# Altium schematic sheet Coil_switch.SchDoc(Coil_switch)
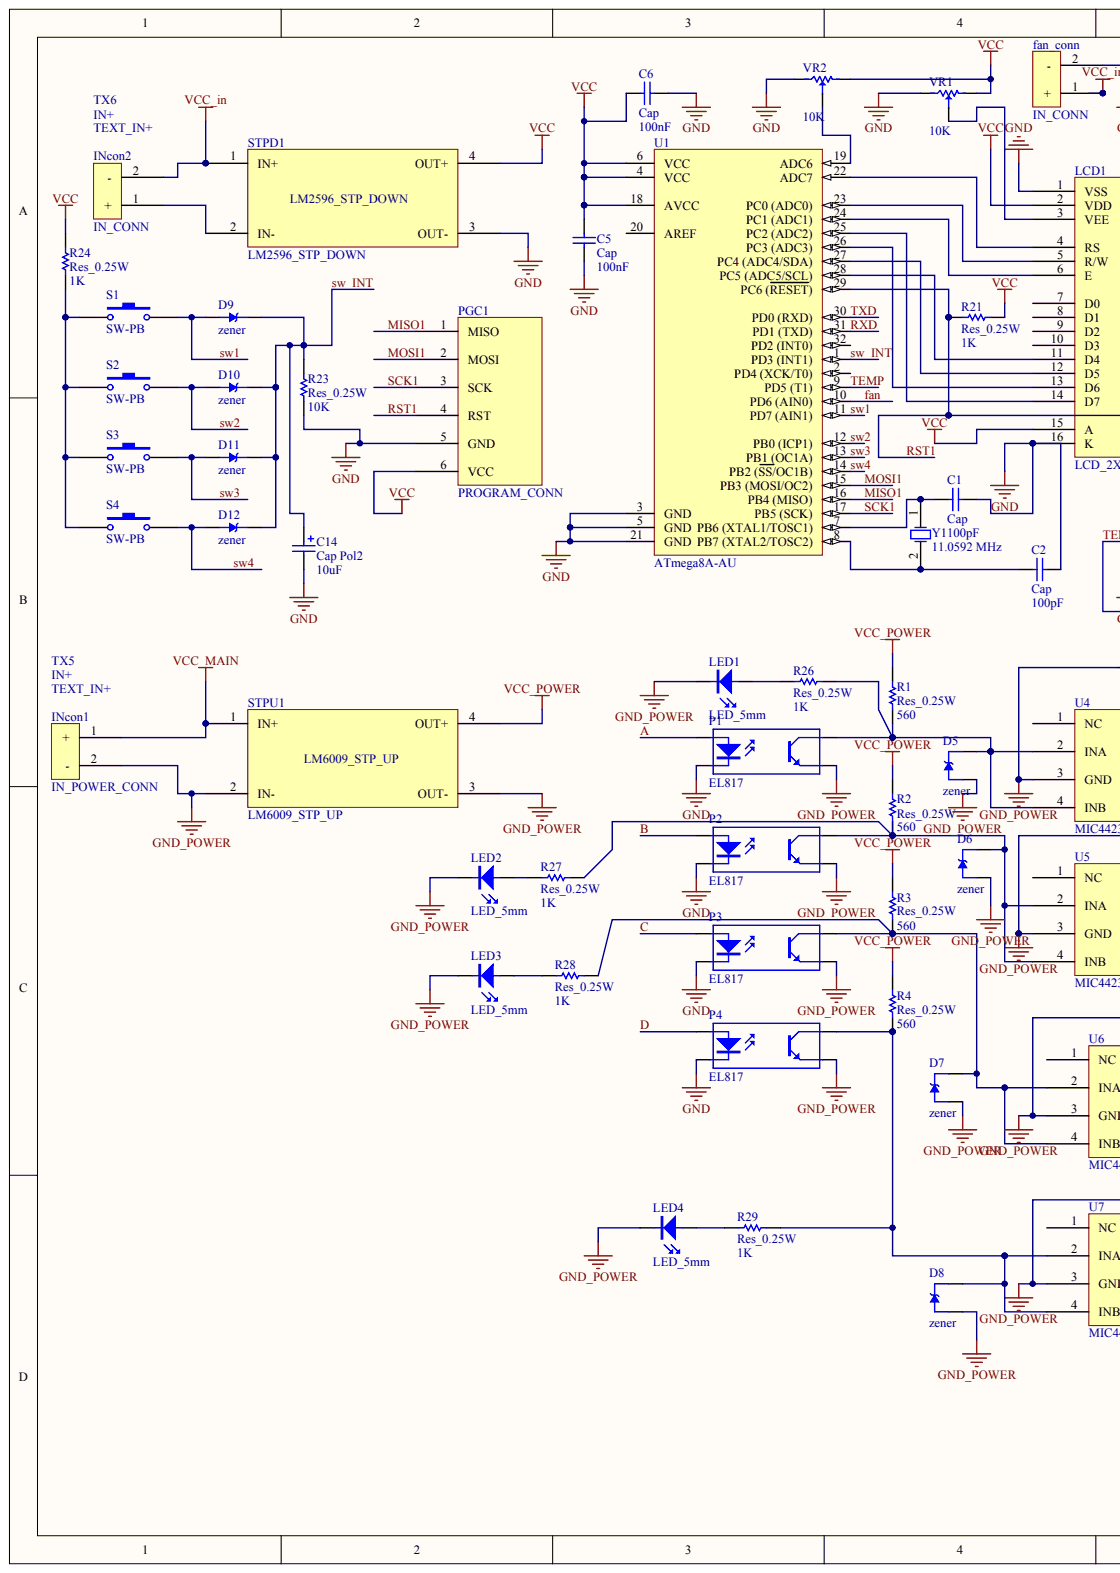
[diagram p0: Coil_switch.SchDoc, left]
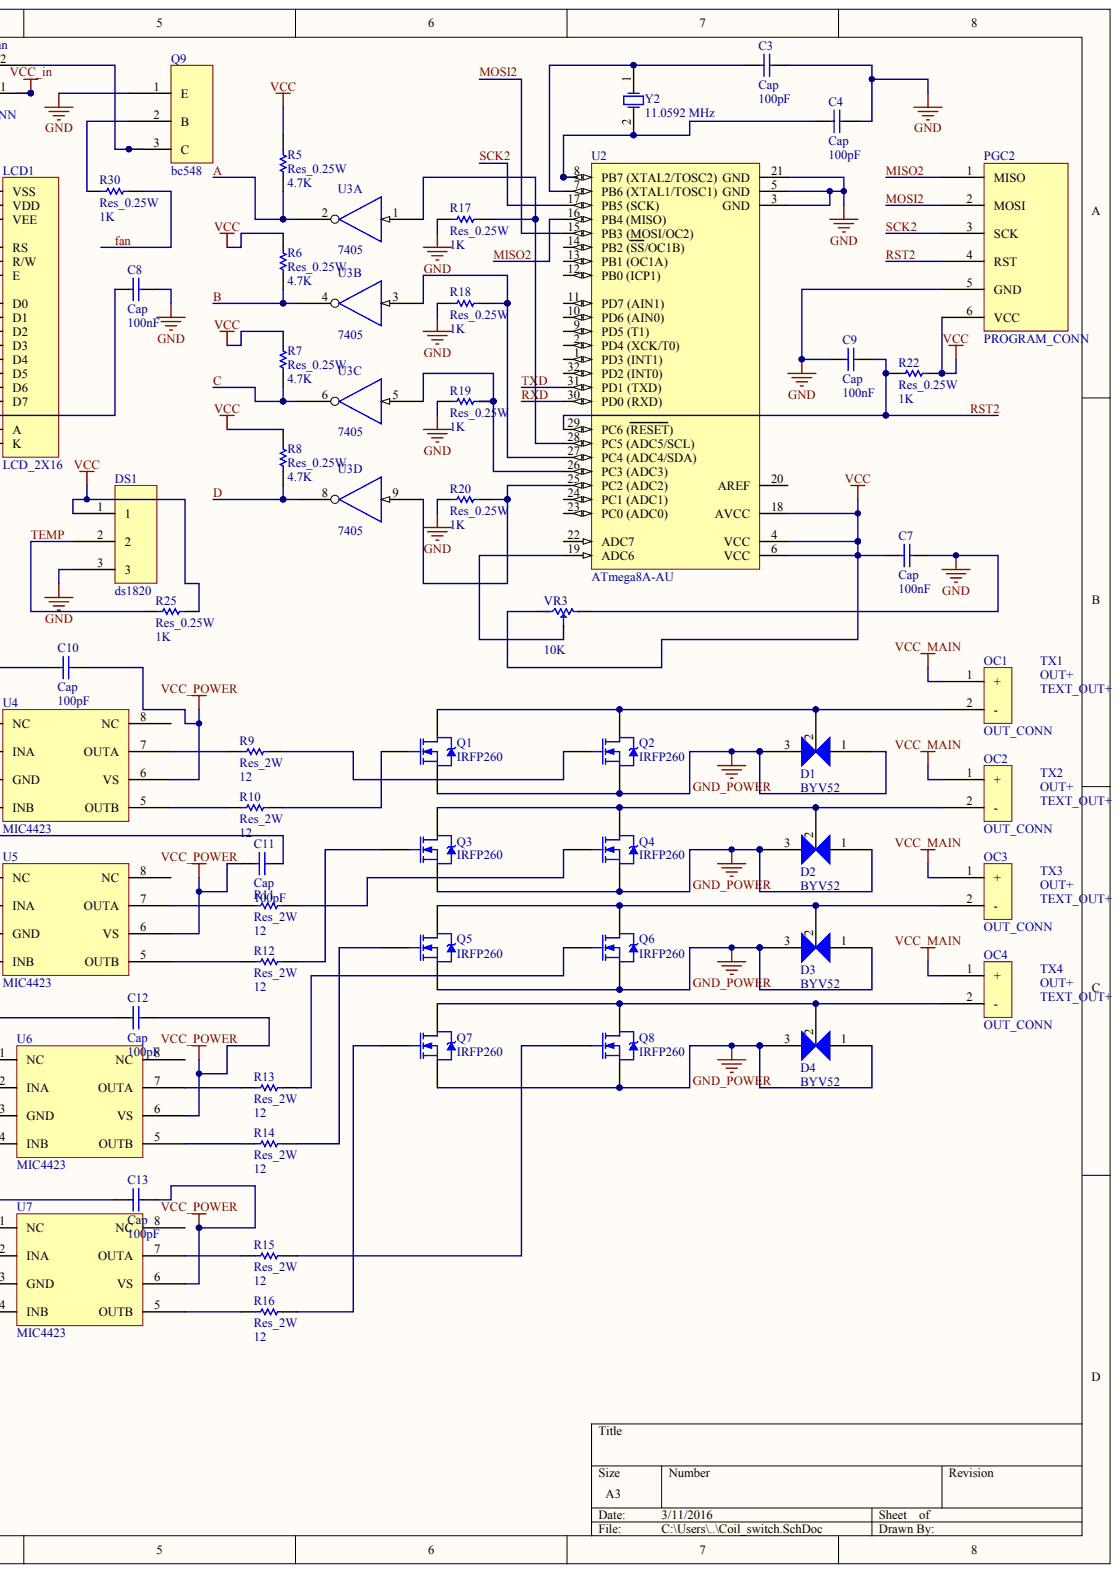
[diagram p1: Coil_switch.SchDoc, right]

## Parts
C1  Cap  value 100pF
C2  Cap  value 100pF
C3  Cap  value 100pF
C4  Cap  value 100pF
C5  Cap  value 100nF
C6  Cap  value 100nF
C7  Cap  value 100nF
C8  Cap  value 100nF
C9  Cap  value 100nF
C10  Cap  value 100pF
C11  Cap  value 100pF
C12  Cap  value 100pF
C13  Cap  value 100pF
C14  Cap Pol2  value 10uF
D1  BYV52
D2  BYV52
D3  BYV52
D4  BYV52
D5  zener
D6  zener
D7  zener
D8  zener
D9  zener
D10  zener
D11  zener
D12  zener
DS1  ds1820
fan_conn  IN_CONN
INcon1  IN_POWER_CONN
INcon2  IN_CONN
LCD1  LCD_2X16
LED1  LED_5mm
LED2  LED_5mm
LED3  LED_5mm
LED4  LED_5mm
OC1  OUT_CONN
OC2  OUT_CONN
OC3  OUT_CONN
OC4  OUT_CONN
P1  EL817
P2  EL817
P3  EL817
P4  EL817
PGC1  PROGRAM_CONN
PGC2  PROGRAM_CONN
Q1  IRFP260
Q2  IRFP260
Q3  IRFP260
Q4  IRFP260
Q5  IRFP260
Q6  IRFP260
Q7  IRFP260
Q8  IRFP260
Q9  bc548
R1  Res_0.25W  value 560
R2  Res_0.25W  value 560
R3  Res_0.25W  value 560
R4  Res_0.25W  value 560
R5  Res_0.25W  value 4.7K
R6  Res_0.25W  value 4.7K
R7  Res_0.25W  value 4.7K
R8  Res_0.25W  value 4.7K
R9  Res_2W
R10  Res_2W  value 12
R11  Res_2W
R12  Res_2W  value 12
R13  Res_2W
R14  Res_2W  value 12
R15  Res_2W
R16  Res_2W  value 12
R17  Res_0.25W  value 1K
R18  Res_0.25W  value 1K
R19  Res_0.25W  value 1K
R20  Res_0.25W  value 1K
R21  Res_0.25W  value 1K
R22  Res_0.25W  value 1K
R23  Res_0.25W  value 10K
R24  Res_0.25W  value 1K
R25  Res_0.25W  value 1K
R26  Res_0.25W  value 1K
R27  Res_0.25W  value 1K
R28  Res_0.25W  value 1K
R29  Res_0.25W  value 1K
R30  Res_0.25W  value 1K
S1  SW-PB
S2  SW-PB
S3  SW-PB
S4  SW-PB
STPD1  LM2596_STP_DOWN
STPU1  LM6009_STP_UP
TX1  TEXT_OUT+
TX2  TEXT_OUT+
TX3  TEXT_OUT+
TX4  TEXT_OUT+
TX5  TEXT_IN+
TX6  TEXT_IN+
U1  ATmega8A-AU
U2  ATmega8A-AU
U3  7405  (parts A,B,C,D)
U4  MIC4423
U5  MIC4423
U6  MIC4423
U7  MIC4423
VR1  10K
VR2  10K
VR3  10K
Y1  11.0592 MHz
Y2  11.0592 MHz

## Nets
GND: C1.2 C2.2 C3.2 C4.2 C5.2 C6.2 C7.2 C8.2 C9.1 C14.2 DS1.3 LCD1.1(VSS) LCD1.16(K) P1.2 P2.2 P3.2 P4.2 PGC1.5(GND) PGC2.5(GND) Q9.1 R17.1 R18.1 R19.1 R20.1 R23.1 STPD1.3(OUT-) U1.3(GND) U1.5(GND) U1.21(GND) U2.3(GND) U2.5(GND) U2.21(GND) U3.7 VR1.1 VR2.1 VR3.2
GND_POWER: C10.1 C11.2 C12.1 C13.1 D1.1 D1.3 D2.1 D2.3 D3.1 D3.3 D4.1 D4.3 D5.1 D6.1 D7.1 D8.1 INcon1.2 LED1.2 LED2.2 LED3.2 LED4.2 P1.3 P2.3 P3.3 P4.3 Q1.3 Q2.3 Q3.3 Q4.3 Q5.3 Q6.3 Q7.3 Q8.3 STPU1.2(IN-) STPU1.3(OUT-) U4.3(GND) U5.3(GND) U6.3(GND) U7.3(GND)
VCC: C5.1 C6.1 C7.1 DS1.1 LCD1.2(VDD) LCD1.15(A) PGC1.6(VCC) PGC2.6(VCC) R5.1 R6.1 R7.1 R8.1 R21.2 R22.2 R24.2 R25.2 STPD1.4(OUT+) U1.4(VCC) U1.6(VCC) U1.18(AVCC) U2.4(VCC) U2.6(VCC) U2.18(AVCC) U3.14 VR1.2 VR2.2 VR3.1
VCC_IN: fan_conn.1 INcon2.2 STPD1.1(IN+)
VCC_MAIN: INcon1.1 OC1.1 OC2.1 OC3.1 OC4.1 STPU1.1(IN+)
VCC_POWER: C10.2 C11.1 C12.2 C13.2 R1.2 R2.2 R3.2 R4.2 STPU1.4(OUT+) U4.6(VS) U5.6(VS) U6.6(VS) U7.6(VS)
A: P1.1 R5.2 U3.2
B: P2.1 R6.2 U3.4
C: P3.1 R7.2 U3.6
D: P4.1 R8.2 U3.8
FAN: R30.2 U1.10(PD6_(AIN0))
MISO1: PGC1.1(MISO) U1.16(PB4_(MISO))
MISO2: PGC2.1(MISO) U2.16(PB4_(MISO))
MOSI1: PGC1.2(MOSI) U1.15(PB3_(MOSI/OC2))
MOSI2: PGC2.2(MOSI) U2.15(PB3_(MOSI/OC2))
RST1: C8.1 PGC1.4(RST) R21.1 U1.29(PC6_(RESET))
RST2: C9.2 PGC2.4(RST) R22.1 U2.29(PC6_(RESET))
RXD: U1.31(PD1_(TXD)) U2.30(PD0_(RXD))
SCK1: PGC1.3(SCK) U1.17(PB5_(SCK))
SCK2: PGC2.3(SCK) U2.17(PB5_(SCK))
SW1: D9.1 S1.2,3 U1.11(PD7_(AIN1))
SW2: D10.1 S2.2,3 U1.12(PB0_(ICP1))
SW3: D11.1 S3.2,3 U1.13(PB1_(OC1A))
SW4: D12.1 S4.2,3 U1.14(PB2_(SS/OC1B))
SW_INT: C14.1 D9.2 D10.2 D11.2 D12.2 R23.2 U1.1(PD3_(INT1))
TEMP: DS1.2 R25.1 U1.9(PD5_(T1))
TXD: U1.30(PD0_(RXD)) U2.31(PD1_(TXD))
NetC1_1: C1.1 U1.7 Y1.1
NetC2_1: C2.1 U1.8 Y1.2
NetC3_1: C3.1 U2.7(PB6_(XTAL1/TOSC1)) Y2.1
NetC4_1: C4.1 U2.8(PB7_(XTAL2/TOSC2)) Y2.2
NetD1_2: D1.2 OC1.2 Q1.2 Q2.2
NetD2_2: D2.2 OC2.2 Q3.2 Q4.2
NetD3_2: D3.2 OC3.2 Q5.2 Q6.2
NetD4_2: D4.2 OC4.2 Q7.2 Q8.2
NetD5_2: D5.2 P1.4 R1.1 R26.2 U4.2(INA) U4.4(INB)
NetD6_2: D6.2 P2.4 R2.1 R27.1 U5.2(INA) U5.4(INB)
NetD7_2: D7.2 P3.4 R3.1 R28.2 U6.2(INA) U6.4(INB)
NetD8_2: D8.2 P4.4 R4.1 R29.2 U7.2(INA) U7.4(INB)
Netfan_conn_2: fan_conn.2 Q9.3
NetINcon2_1: INcon2.1 STPD1.2(IN-)
NetLCD1_3: LCD1.3(VEE) VR1.3
NetLCD1_4: LCD1.4(RS) U1.22(ADC7)
NetLCD1_5: LCD1.5(R/W) U1.23(PC0_(ADC0))
NetLCD1_6: LCD1.6(E) U1.24(PC1_(ADC1))
NetLCD1_11: LCD1.11(D4) U1.28(PC5_(ADC5/SCL))
NetLCD1_12: LCD1.12(D5) U1.27(PC4_(ADC4/SDA))
NetLCD1_13: LCD1.13(D6) U1.26(PC3_(ADC3))
NetLCD1_14: LCD1.14(D7) U1.25(PC2_(ADC2))
NetLED1_1: LED1.1 R26.1
NetLED2_1: LED2.1 R27.2
NetLED3_1: LED3.1 R28.1
NetLED4_1: LED4.1 R29.1
NetQ1_1: Q1.1 R10.2
NetQ2_1: Q2.1 R9.2
NetQ3_1: Q3.1 R12.2
NetQ4_1: Q4.1 R11.2
NetQ5_1: Q5.1 R14.2
NetQ6_1: Q6.1 R13.2
NetQ7_1: Q7.1 R16.2
NetQ8_1: Q8.1 R15.2
NetQ9_2: Q9.2 R30.1
NetR9_1: R9.1 U4.7(OUTA)
NetR10_1: R10.1 U4.5(OUTB)
NetR11_1: R11.1 U5.7(OUTA)
NetR12_1: R12.1 U5.5(OUTB)
NetR13_1: R13.1 U6.7(OUTA)
NetR14_1: R14.1 U6.5(OUTB)
NetR15_1: R15.1 U7.7(OUTA)
NetR16_1: R16.1 U7.5(OUTB)
NetR17_2: R17.2 U2.28(PC5_(ADC5/SCL)) U3.1
NetR18_2: R18.2 U2.27(PC4_(ADC4/SDA)) U3.3
NetR19_2: R19.2 U2.26(PC3_(ADC3)) U3.5
NetR20_2: R20.2 U2.25(PC2_(ADC2)) U3.9
NetR24_1: R24.1 S1.1,4 S2.1,4 S3.1,4 S4.1,4
NetU1_19: U1.19(ADC6) VR2.3
NetU2_19: U2.19(ADC6) VR3.3

Not connected: LCD1 7(D0) 8(D1) 9(D2) 10(D3); U1 2(PD4_(XCK/T0)) 20(AREF) 32(PD2_(INT0)); U2 1(PD3_(INT1)) 2(PD4_(XCK/T0)) 9(PD5_(T1)) 10(PD6_(AIN0)) 11(PD7_(AIN1)) 12(PB0_(ICP1)) 13(PB1_(OC1A)) 14(PB2_(SS/OC1B)) 20(AREF) 22(ADC7) 23(PC0_(ADC0)) 24(PC1_(ADC1)) 32(PD2_(INT0)); U4 1(NC) 8(NC); U5 1(NC) 8(NC); U6 1(NC) 8(NC); U7 1(NC) 8(NC)

## Library data of the parts
C1 C10-C13 = (no symbol name): Value: 100pF; Published: 8-Jun-2000; LatestRevisionDate: 17-Jul-2002; LatestRevisionNote: Re-released for DXP Platform.; PackageReference: RAD-0.3; PackageDescription: Radial Cap, Thru-Hole; 2 Leads; 0.3 in Pin Spacing; Publisher: Altium Limited
C6 = (no symbol name): Value: 100nF; Published: 8-Jun-2000; LatestRevisionDate: 17-Jul-2002; LatestRevisionNote: Re-released for DXP Platform.; PackageReference: RAD-0.3; PackageDescription: Radial Cap, Thru-Hole; 2 Leads; 0.3 in Pin Spacing; Publisher: Altium Limited
R9 R11 R13 R15 = (no symbol name): Value: 12; Published: 8-Jun-2000; LatestRevisionDate: 17-Jul-2002; LatestRevisionNote: Re-released for DXP Platform.; PackageReference: AXIAL-0.3; PackageDescription: Axial Device, Thru-Hole; 2 Leads; 0.3 in Pin Spacing; Publisher: Altium Limited
VR1-VR3 = (no symbol name): Designer: Saeid Jorablo; Company: ControTec; Adding Date: 137/12/22; Value: 10K
Y1 Y2 = (no symbol name): Company: E.P.I.L; Design Date: 11/04/2011; Value: 11.0592 MHz
C2-C5 C7-C9 C14 D1-D12 DS1 fan_conn INcon1 INcon2 LCD1 LED1-LED4 OC1-OC4 P1-P4 PGC1 PGC2 Q1-Q9 R1-R8 R10 R12 R14 R16-R30 S1-S4 STPD1 STPU1 TX1-TX6 U1-U7 = (no symbol name)
  C2-C5 C7-C9: Published: 8-Jun-2000; LatestRevisionDate: 17-Jul-2002; LatestRevisionNote: Re-released for DXP Platform.; PackageReference: RAD-0.3; PackageDescription: Radial Cap, Thru-Hole; 2 Leads; 0.3 in Pin Spacing
  C14: Published: 8-Jun-2000; LatestRevisionDate: 17-Jul-2002; LatestRevisionNote: Re-released for DXP Platform.; PackageReference: RB7.6-15; PackageDescription: Polarized Capacitor (Radial); 2 Leads
  R1-R8 R10 R12 R14 R16-R30: Published: 8-Jun-2000; LatestRevisionDate: 17-Jul-2002; LatestRevisionNote: Re-released for DXP Platform.; PackageReference: AXIAL-0.3; PackageDescription: Axial Device, Thru-Hole; 2 Leads; 0.3 in Pin Spacing
  S1-S4: Published: 24-Mar-1999; LatestRevisionDate: 17-Jul-2002; LatestRevisionNote: Re-released for DXP Platform.; PackageReference: SPST-2; PackageDescription: Switch; 2 Leads
  U1 U2: Published: 1-Apr-2010; DatasheetVersion: revC, Jul-2009; PackageVersion: revB, Oct-2001; PackageReference: 32A; PackageDescription: 32-Lead, 7x7x1.0 mm Body, 0.8mm Lead Pitch, Thin Profile Plastic Quad Flat Package (TQFP); Supplier 1: Digi-Key; Supplier Part Number 1: ATMEGA8A-AU-ND; Supplier 2: Farnell; Supplier Part Number 2: 1748532; Supplier 3: Mouser; Supplier Part Number 3: 556-ATMEGA8A-AU; Code_JEDEC: MS-026-ABA; ComponentLink1Description: Manufacturer Link; ComponentLink1URL: http://www.atmel.com/products/avr/default.asp?; ComponentLink2Description: ATmega8A Datasheet; ComponentLink2URL: http://www.atmel.com/dyn/resources/prod_documents/doc8159.pdf; ComponentLink3Description: ATmega8A Summary; ComponentLink3URL: http://www.atmel.com/dyn/resources/prod_documents/8159S.pdf; ComponentLink4Description: Atmel.com Home; ComponentLink4URL: http://www.atmel.com
  C2-C5 C7-C9 C14 R1-R8 R10 R12 R14 R16-R30 S1-S4 U1 U2: Publisher: Altium Limited
